# Altium schematic sheet MPU-6050_Main_SCH.SchDoc
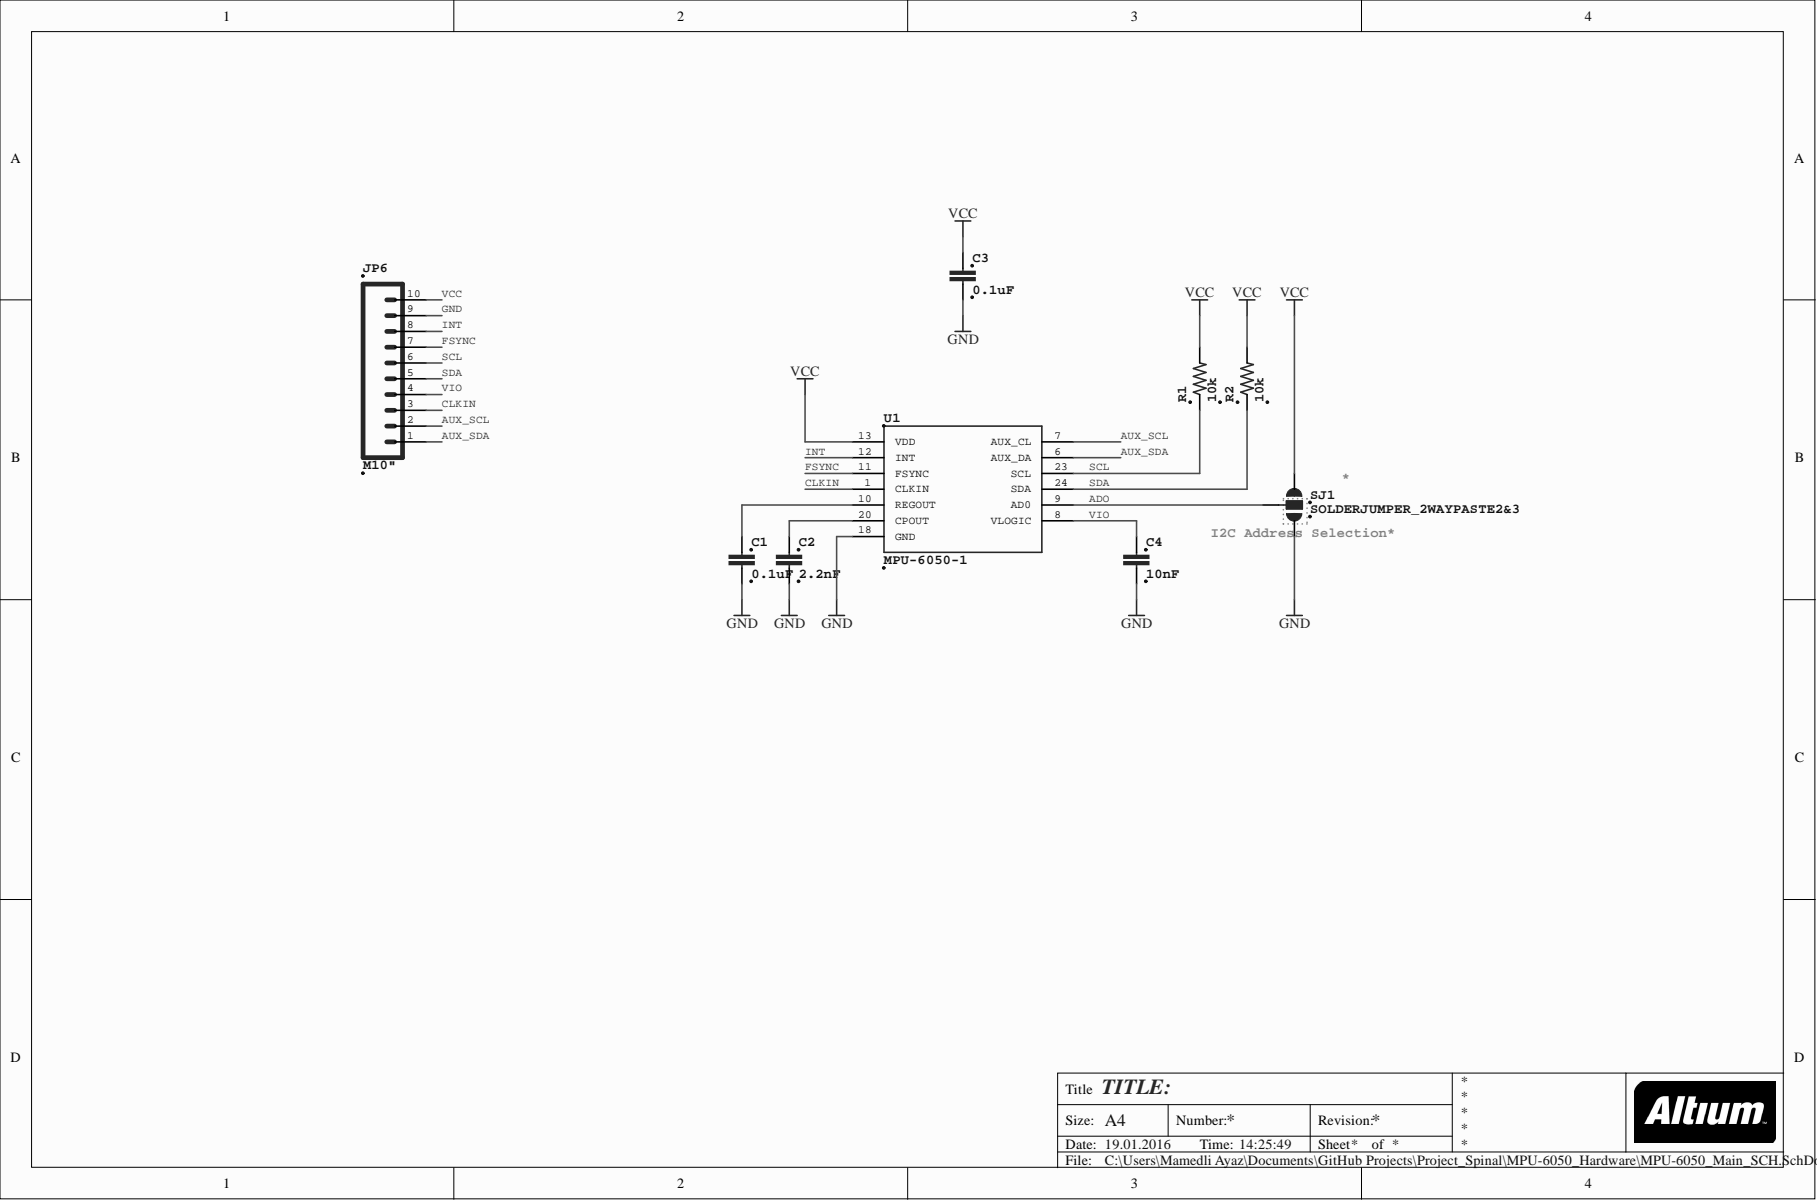
[diagram p0: MPU-6050_Main_SCH.SchDoc]

## Parts
C1  0.1uF  >NAME: C1  [0603-CAP]
C2  2.2nF  >NAME: C2  [0603-CAP]
C3  0.1uF  >NAME: C3  [0603-CAP]
C4  10nF  >NAME: C4  [0603-CAP]
JP6  M10"  >NAME: JP6  [1X10]
R1  10k  >NAME: R1  [0603-RES]
R2  10k  >NAME: R2  [0603-RES]
SJ1  SOLDERJUMPER_2WAYPASTE2&3  >NAME: SJ1  [SJ_3_PASTE2&3]
U1  MPU-6050-1  >NAME: U1  [QFN-24-4MM2]

## Nets
ADO: SJ1.2 U1.9(AD0)
AUX_SCL: JP6.2 U1.7(AUX_CL)
AUX_SDA: JP6.1 U1.6(AUX_DA)
CLKIN: JP6.3 U1.1(CLKIN)
FSYNC: JP6.7 U1.11(FSYNC)
GND: C1.2 C2.2 C3.2 C4.2 JP6.9 SJ1.3 U1.18(GND)
INT: JP6.8 U1.12(INT)
SCL: JP6.6 R1.1 U1.23(SCL)
SDA: JP6.5 R2.1 U1.24(SDA)
VCC: C3.1 JP6.10 R1.2 R2.2 SJ1.1 U1.13(VDD)
VIO: C4.1 JP6.4 U1.8(VLOGIC)
NetC1_1: C1.1 U1.10(REGOUT)
NetC2_1: C2.1 U1.20(CPOUT)

## Library data of the parts
C1-C4 = CAP0603-CAP: <b>Capacitor</b> Standard 0603 ceramic capacitor, and 0.1" leaded capacitor.; Component Kind: Standard; Library Name: SparkFun.IntLib
  C1 C3: >VALUE: 0.1uF
  C2: >VALUE: 2.2nF
  C4: >VALUE: 10nF
JP6 = M10": <b>Header 10</b> Standard 10-pin 0.1" header. Use with straight break away headers (SKU : PRT-00116), right angle break away headers (PRT-00553), swiss pins (PRT-00743), machine pins (PRT-00117), and female headers (PRT-00115).; >VALUE: M10"; Component Kind: Standard; Library Name: SparkFun.IntLib
R1 R2 = RESISTOR0603-RES: <b>Resistor</b> Basic schematic elements and footprints for 0603, 1206, and PTH resistors.; >VALUE: 10k; Component Kind: Standard; Library Name: SparkFun.IntLib
SJ1 = SOLDERJUMPER_2WAYPASTE2&3: <b>Solder Jumper</b> 2 way solder jumper; >VALUE: SOLDERJUMPER_2WAYPASTE2&3; Component Kind: Standard; Library Name: SparkFun.IntLib
U1 = MPU-6050-1: >VALUE: MPU-6050-1; Component Kind: Standard; Library Name: Testing.IntLib
